# Altium schematic sheet STM32.SchDoc(STM32)
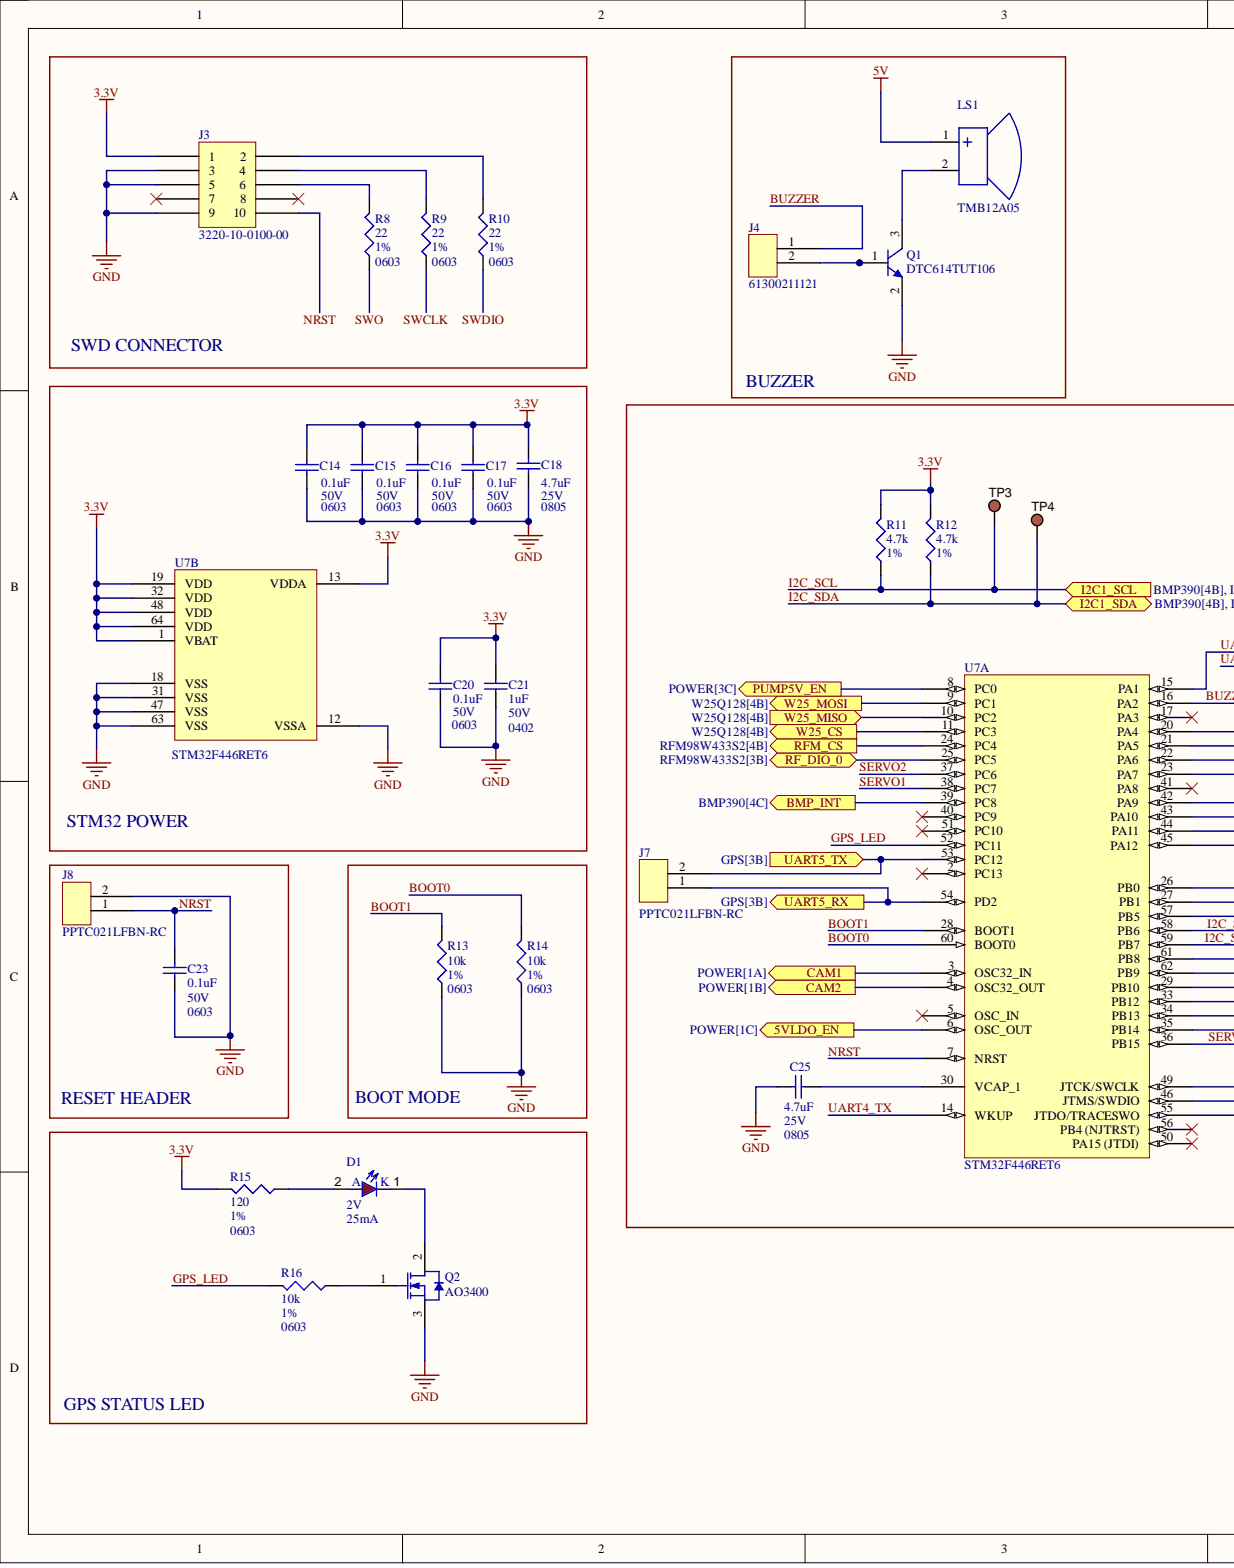
[diagram p0: STM32.SchDoc, left]
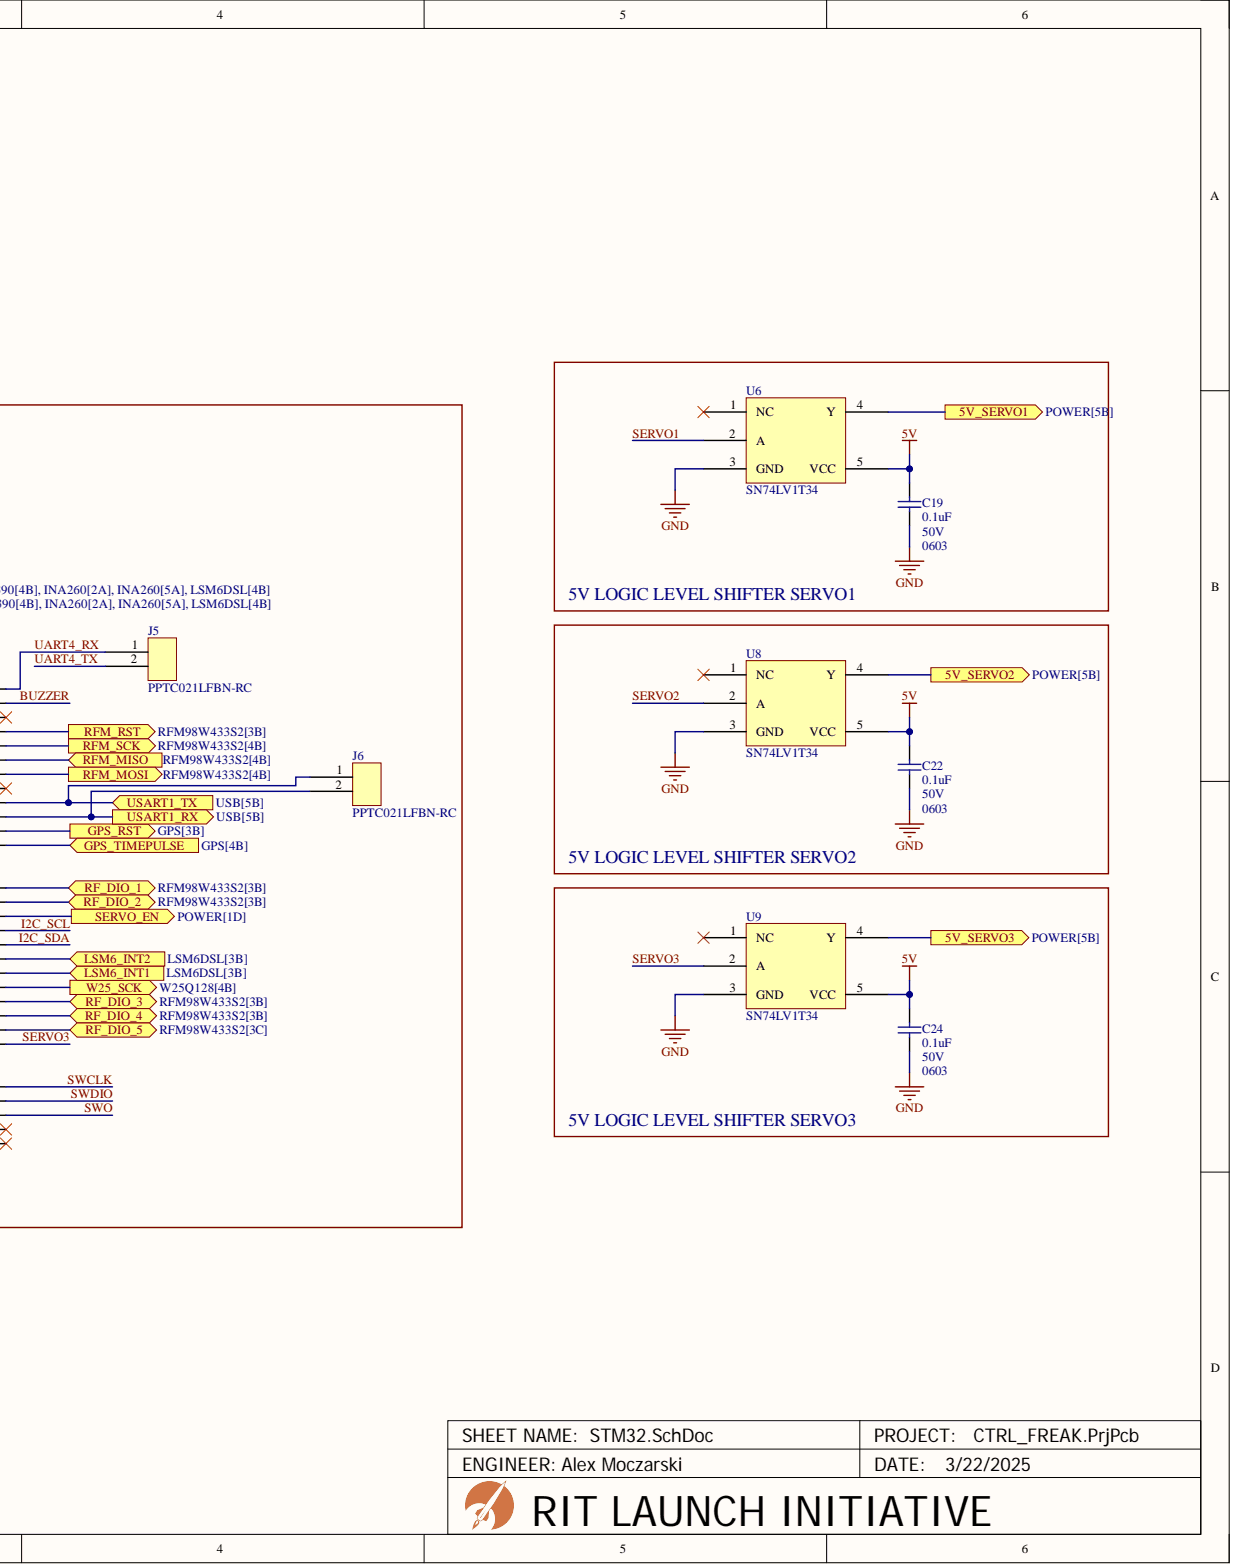
[diagram p1: STM32.SchDoc, right]

## Parts
C14  Capacitance: 0.1uF  Case Code (Imperial): 0603  Voltage: 50V  (hidden comment: CC0603KRX7R9BB104)
C15  Capacitance: 0.1uF  Case Code (Imperial): 0603  Voltage: 50V  (hidden comment: CC0603KRX7R9BB104)
C16  Capacitance: 0.1uF  Case Code (Imperial): 0603  Voltage: 50V  (hidden comment: CC0603KRX7R9BB104)
C17  Capacitance: 0.1uF  Case Code (Imperial): 0603  Voltage: 50V  (hidden comment: CC0603KRX7R9BB104)
C18  Capacitance: 4.7uF  Case/Package: 0805  Voltage: 25V  (hidden comment: CL21A475KAQNNNE)
C19  Capacitance: 0.1uF  Case Code (Imperial): 0603  Voltage: 50V  (hidden comment: CC0603KRX7R9BB104)
C20  Capacitance: 0.1uF  Case Code (Imperial): 0603  Voltage: 50V  (hidden comment: CC0603KRX7R9BB104)
C21  Capacitance: 1uF  Case/Package: 0402  Voltage: 50V  (hidden comment: CL05A105KA5NQNC)
C22  Capacitance: 0.1uF  Case Code (Imperial): 0603  Voltage: 50V  (hidden comment: CC0603KRX7R9BB104)
C23  Capacitance: 0.1uF  Case Code (Imperial): 0603  Voltage: 50V  (hidden comment: CC0603KRX7R9BB104)
C24  Capacitance: 0.1uF  Case Code (Imperial): 0603  Voltage: 50V  (hidden comment: CC0603KRX7R9BB104)
C25  Capacitance: 4.7uF  Case/Package: 0805  Voltage: 25V  (hidden comment: CL21A475KAQNNNE)
D1  Forward Voltage: 2V  Max Current: 25mA  (hidden comment: NCD0805R1)
J3  3220-10-0100-00
J4  61300211121
J5  PPTC021LFBN-RC
J6  PPTC021LFBN-RC
J7  PPTC021LFBN-RC
J8  PPTC021LFBN-RC
LS1  TMB12A05
Q1  DTC614TUT106
Q2  AO3400
R8  Case/Package: 0603  Tolerance: 1%  (hidden comment: 0603WAF220JT5E)
R9  Case/Package: 0603  Tolerance: 1%  (hidden comment: 0603WAF220JT5E)
R10  Case/Package: 0603  Tolerance: 1%  (hidden comment: 0603WAF220JT5E)
R11  Resistance: 4.7k  Tolerance: 1%  (hidden comment: 0603WAF4701T5E)
R12  Resistance: 4.7k  Tolerance: 1%  (hidden comment: 0603WAF4701T5E)
R13  Case/Package: 0603  Resistance: 10k  Tolerance: 1%  (hidden comment: 0603WAF1002T5E)
R14  Case/Package: 0603  Resistance: 10k  Tolerance: 1%  (hidden comment: 0603WAF1002T5E)
R15  Case/Package: 0603  Tolerance: 1%  (hidden comment: 0603WAF1200T5E)
R16  Case/Package: 0603  Resistance: 10k  Tolerance: 1%  (hidden comment: 0603WAF1002T5E)
TP3  5003  (value not drawn)
TP4  5003  (value not drawn)
U6  SN74LV1T34
U7  STM32F446RET6  (parts A,B)
U8  SN74LV1T34
U9  SN74LV1T34

## Ports
5V_SERVO1, 5V_SERVO2, 5V_SERVO3, 5VLDO_EN, BMP_INT, CAM1, CAM2, GPS_RST, GPS_TIMEPULSE, I2C1_SCL, I2C1_SDA, LSM6_INT1, LSM6_INT2, PUMP5V_EN, RF_DIO_0, RF_DIO_1, RF_DIO_2, RF_DIO_3, RF_DIO_4, RF_DIO_5, RFM_CS, RFM_MISO, RFM_MOSI, RFM_RST, RFM_SCK, SERVO_EN, UART5_RX, UART5_TX, USART1_RX, USART1_TX, W25_CS, W25_MISO, W25_MOSI, W25_SCK

## Nets
3.3V: C14.2 C15.2 C16.2 C17.2 C18.2 C20.2 C21.2 J3.1 R11.2 R12.2 R15.2 U7.1(VBAT) U7.13(VDDA) U7.19(VDD) U7.32(VDD) U7.48(VDD) U7.64(VDD)
5V: C19.2 C22.2 C24.2 LS1.1 U6.5(VCC) U8.5(VCC) U9.5(VCC)
GND: C14.1 C15.1 C16.1 C17.1 C18.1 C19.1 C20.1 C21.1 C22.1 C23.1 C24.1 C25.1 J3.3 J3.5 J3.9 J8.2 Q1.2 Q2.3 R13.1 R14.1 U6.3(GND) U7.12(VSSA) U7.18(VSS) U7.31(VSS) U7.47(VSS) U7.63(VSS) U8.3(GND) U9.3(GND)
5V_SERVO1: U6.4(Y) ; port 5V_SERVO1
5V_SERVO2: U8.4(Y) ; port 5V_SERVO2
5V_SERVO3: U9.4(Y) ; port 5V_SERVO3
5VLDO_EN: U7.6(OSC_OUT) ; port 5VLDO_EN
BMP_INT: U7.39(PC8) ; port BMP_INT
BOOT0: R14.2 U7.60(BOOT0)
BOOT1: R13.2 U7.28(BOOT1)
BUZZER: J4.1 U7.16(PA2)
CAM1: U7.3(OSC32_IN) ; port CAM1
CAM2: U7.4(OSC32_OUT) ; port CAM2
GPS_LED: R16.2 U7.52(PC11)
GPS_RST: U7.44(PA11) ; port GPS_RST
GPS_TIMEPULSE: U7.45(PA12) ; port GPS_TIMEPULSE
I2C_SCL: R11.1 TP3.1 U7.58(PB6) ; port I2C1_SCL
I2C_SDA: R12.1 TP4.1 U7.59(PB7) ; port I2C1_SDA
LSM6_INT1: U7.62(PB9) ; port LSM6_INT1
LSM6_INT2: U7.61(PB8) ; port LSM6_INT2
NRST: C23.2 J3.10 J8.1 U7.7(NRST)
PUMP5V_EN: U7.8(PC0) ; port PUMP5V_EN
RF_DIO_0: U7.25(PC5) ; port RF_DIO_0
RF_DIO_1: U7.26(PB0) ; port RF_DIO_1
RF_DIO_2: U7.27(PB1) ; port RF_DIO_2
RF_DIO_3: U7.33(PB12) ; port RF_DIO_3
RF_DIO_4: U7.34(PB13) ; port RF_DIO_4
RF_DIO_5: U7.35(PB14) ; port RF_DIO_5
RFM_CS: U7.24(PC4) ; port RFM_CS
RFM_MISO: U7.22(PA6) ; port RFM_MISO
RFM_MOSI: U7.23(PA7) ; port RFM_MOSI
RFM_RST: U7.20(PA4) ; port RFM_RST
RFM_SCK: U7.21(PA5) ; port RFM_SCK
SERVO1: U6.2(A) U7.38(PC7)
SERVO2: U7.37(PC6) U8.2(A)
SERVO3: U7.36(PB15) U9.2(A)
SERVO_EN: U7.57(PB5) ; port SERVO_EN
SWCLK: R9.1 U7.49(JTCK/SWCLK)
SWDIO: R10.1 U7.46(JTMS/SWDIO)
SWO: R8.1 U7.55(JTDO/TRACESWO)
UART4_RX: J5.1 U7.15(PA1)
UART4_TX: J5.2 U7.14(WKUP)
UART5_RX: J7.1 U7.54(PD2) ; port UART5_RX
UART5_TX: J7.2 U7.53(PC12) ; port UART5_TX
USART1_RX: J6.2 U7.43(PA10) ; port USART1_RX
USART1_TX: J6.1 U7.42(PA9) ; port USART1_TX
W25_CS: U7.11(PC3) ; port W25_CS
W25_MISO: U7.10(PC2) ; port W25_MISO
W25_MOSI: U7.9(PC1) ; port W25_MOSI
W25_SCK: U7.29(PB10) ; port W25_SCK
NetC25_2: C25.2 U7.30(VCAP_1)
NetD1_1: D1.1 Q2.2
NetD1_2: D1.2 R15.1
NetJ3_2: J3.2 R10.2
NetJ3_4: J3.4 R9.2
NetJ3_6: J3.6 R8.2
NetJ4_2: J4.2 Q1.1
NetLS1_2: LS1.2 Q1.3
NetQ2_1: Q2.1 R16.1

Not connected: J3 7 8; U6 1(NC); U7 2(PC13) 5(OSC_IN) 17(PA3) 40(PC9) 41(PA8) 50(PA15_(JTDI)) 51(PC10) 56(PB4_(NJTRST)); U8 1(NC); U9 1(NC)

## Library data of the parts
C14-C17 C19 C20 C22-C24 = CMP-001-0242-3: CAP CER 0.1UF 50V X7R 0603; Dielectric Material: Ceramic; Tolerance: 10%; Case Code (Metric): 1608; Case/Package: 0603; Component Kind: Standard; Depth: 0.8mm; Dielectric: X7R; Halogen Free: Halogen Free; Height: 0.8mm; JLCPCB Part #: C14663; Lead Free: Lead Free; Length: 0.063inch; Max Operating Temperature: 125°C; Min Operating Temperature: -55°C; Mount: Surface Mount; Number of Pins: 2; Package Quantity: 1; Packaging: Tape and Reel; Radiation Hardening: No; REACH SVHC: No SVHC; RoHS Compliant: Yes; Series: CC; Termination: SMD/SMT; Thickness: 0.035inch; Voltage Rating: 50V; Width: 0.031inch; Library Name: RIT Launch Initiative
C18 C25 = CMP-001-0285-2: 25V 4.7uF X5R ±10% 0805 Multilayer Ceramic Capacitors MLCC - SMD/SMT ROHS; Value: 4.7µF; Capacitor Type: Ceramic; Component Kind: Standard; JLCPCB Part #: C1779; Max Operating Temperature: 85°C; Min Operating Temperature: -55°C; Mounting Technology: Surface Mount; Package: 0805; Pins: 2; RoHS Compliant: Yes; Library Name: RIT Launch Initiative
C21 = CMP-001-0296-1: CAP CER 1uF 50V X5R 0402; Material: Ceramic; Tolerance: 10%; Component Kind: Standard; Dielectric: X5R; JLCPCB Part #: C52923; Max Operating Temperature: 85°C; Min Operating Temperature: -55°C; Mounting Technology: Surface Mount; Series: CL; Library Name: RIT Launch Initiative
D1 = CMP-001-0246-3: LED RED CLEAR 0805 SMD; Component Kind: Standard; JLCPCB Part #: C84256; Library Name: RIT Launch Initiative
J3 = CMP-001-0340-1: CONN HEADER VERT 10POS 1.27MM; Number of Positions: 10; Pitch: 0.05inch; Component Kind: Standard; Connector Type: Header; Contact Finish - Mating: GOLD; Contact Material: Brass; Contact Plating: Gold; Contact Shape: Square; Contact Type: Male Pin; Fastening Type: Pull; Flammability Rating: UL94 V-0; Insulation Material: Nylon; Max Operating Temperature: 105°C; Min Operating Temperature: -40°C; Mounting Technology: Through Hole; Number of Rows: 2; Package Quantity: 3200; Packaging: Tray; RoHS Compliant: Yes; Row Spacing: 0.05inch; Series: -; Termination: Solder; Library Name: RIT Launch Initiative
J4 = CMP-001-0080-1: CONN HEADER VERT 2POS 2.54MM; Component Kind: Standard; Library Name: RIT Launch Initiative
J5-J8 = CMP-001-0336-1: CONN HDR 2POS 0.1 TIN PCB; Connector Type: Header; Number of Positions: 2; Pitch: 2.54mm; Voltage Rating: 250V; Color: Black; Component Kind: Standard; Contact Finish - Mating: TIN; Contact Material: Bronze; Contact Plating: Tin; Contact Shape: Square; Contact Type: Female Socket; Fastening Type: Pull; Flammability Rating: UL94 V-0; Height: 8.5mm; Housing Material: Plastic; Insulation Color: Black; Insulation Material: Polyester; Lead Free: Lead Free; Length: 5.58mm; Max Operating Temperature: 105°C; Min Operating Temperature: -40°C; Mounting Technology: Through Hole; Number of Rows: 1; Package Quantity: 1000; Packaging: Tray; RoHS Compliant: Yes; Series: -; Termination: Solder; Width: 2.5mm; Library Name: RIT Launch Initiative
LS1 = CMP-001-0337-1: BUZZER MAGNETIC 5V 12MM TH; Component Kind: Standard; JLCPCB Part #: C781860; Library Name: RIT Launch Initiative
Q1 = CMP-009-00209-5: No Description Available; Mfr_Name: ROHM Semiconductor; Case/Package: SOT-323; Component Kind: Standard; Design Item ID: DTC614TUT106; JLCPCB Part #: C79963; Max Operating Temperature: 150°C; Pins: 3; RoHS Compliant: Yes; Source: DTC614TUT106.SchLib; Library Name: RIT Launch Initiative
Q2 = CMP-001-0454-1: MOSFET N-CH 30V 5.7A SOT23-3L; Gate to Source Voltage (Vgs): 12V; Input Capacitance: 630pF; Max Power Dissipation: 1.4W; Number of Elements: 1; Rds On Max: 26.5mR; Case/Package: TO-236-3; Component Kind: Standard; Continuous Drain Current (ID): 5.7A; Drain to Source Voltage (Vdss): 30V; JLCPCB Part #: C6562205; Lead Free: Lead Free; Max Operating Temperature: 150°C; Min Operating Temperature: -55°C; Mounting Technology: Surface Mount; Packaging: Cut Tape; Pins: 3; Power Dissipation: 1.4W; Radiation Hardening: No; RoHS Compliant: Yes; Series: -; Library Name: RIT Launch Initiative
R8-R10 = CMP-001-0306-1: RES 22 OHM 1% 1/10W 0603; Resistance: 22; Component Kind: Standard; JLCPCB Part #: C23345; RoHS Compliant: Yes; Library Name: RIT Launch Initiative
R11 R12 = CMP-001-0283-3: 100mW Thick Film Resistors 75V ±100ppm/℃ ±1% -55℃~+155℃ 4.7kΩ 0603 Chip Resistor - Surface Mount ROHS; Component Kind: Standard; JLCPCB Part #: C23162; Package: 0603; Library Name: RIT Launch Initiative
R13 R14 R16 = CMP-001-0268-1: RES 10K OHM 1% 1/10W 0603; Component Kind: Standard; JLCPCB Part #: C25804; RoHS Compliant: Yes; Library Name: RIT Launch Initiative
R15 = CMP-001-0272-1: RES 120 OHM 1% 1/10W 0603; Resistance: 120; Component Kind: Standard; JLCPCB Part #: C22787; Library Name: RIT Launch Initiative
TP3 TP4 = CMP-002-00082-1: Test Point, Orange, Height 4.6 mm, Tail Length 3 mm, 1-Pin THD, RoHS; Manufacturer: Keystone; Case/Package: 5003; Colour: Orange; Component Kind: Standard; Connector Type: TestPoint; Contact Material: Bronze; Contact Plating: Tin; Datasheet URL: http://keyelco.com/userAssets/file/M65p56.pdf; Datasheet Version: Jun-15; Diameter: 2.54mm; Manufacturer URL: http://www.keyelco.com/; Mounting Technology: TH; Package Description: 1-Pin Through Hole Device, Dia 2.54 mm; Package Quantity: 10; Package Reference: 5003; Packaging: Bulk; Pins: 1; RoHS Compliant: True; Library Name: RIT Launch Initiative
U6 U8 U9 = CMP-001-0449-3: LOGIC BUFFER; Component Kind: Standard; JLCPCB Part #: C100024; Library Name: RIT Launch Initiative
U7 = CMP-001-0264-3: IC MCU 32BIT 512KB FLASH 64LQFP; Core Architecture: ARM; Data Bus Width: 32b; Frequency: 180MHz; Interface: I2C,SPI,USB,CAN,IrDA,SD,UART,USART,LIN,EBI/EMI; Max Power Dissipation: 345mW; Max Supply Voltage: 3.6V; Min Supply Voltage: 1.7V; Number of I/Os: 50; Number of Timers/Counters: 14; Oscillator Type: Internal; Peripherals: Brown-out Detect/Reset,POR,PWM,WDT,DMA,LVD; RAM Size: 128KB; Watchdog Timer: Yes; Case/Package: LQFP; Component Kind: Standard; JLCPCB Part #: C69336; Lead Free: Lead Free; Max Frequency: 180MHz; Max Operating Temperature: 85°C; Memory Size: 512KB; Memory Type: FLASH; Min Operating Temperature: -40°C; Mount: Surface Mount; Number of Pins: 64; Number of Programmable I/O: 50; Packaging: Tray; REACH SVHC: No SVHC; RoHS Compliant: Yes; Series: STM32 F4; Library Name: RIT Launch Initiative
